# Altium schematic sheet MCU.SchDoc(MCU)
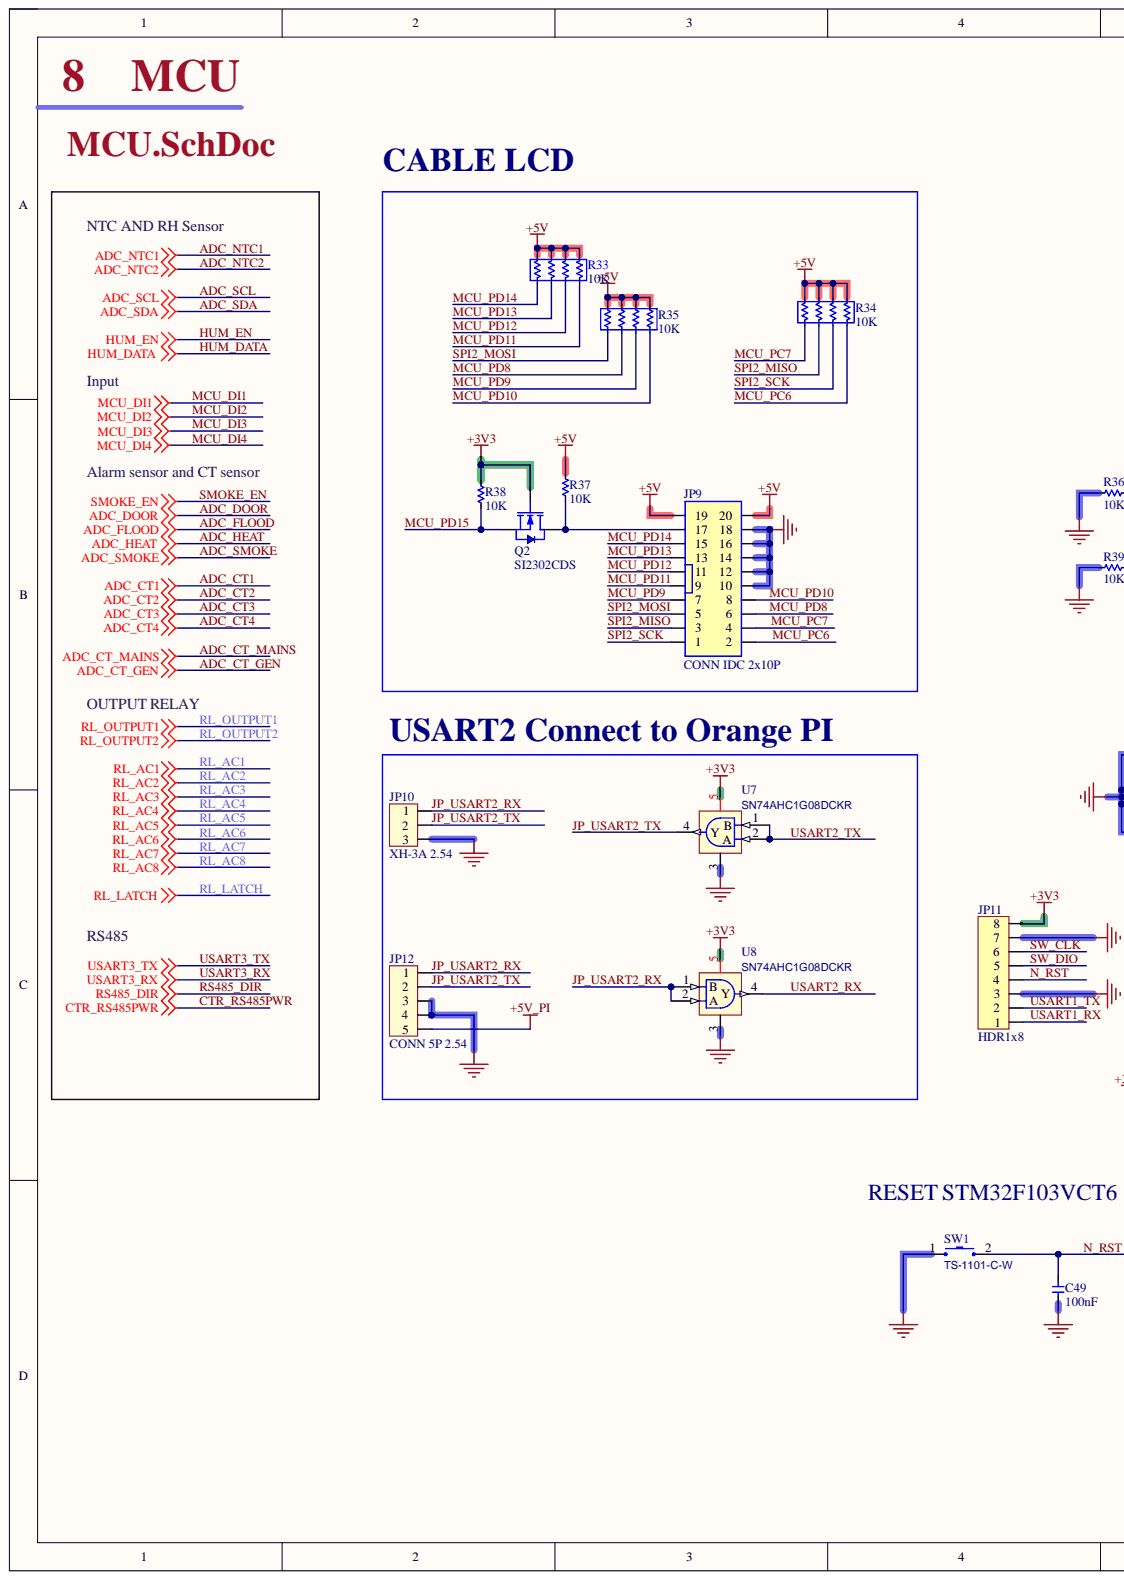
[diagram p0: MCU.SchDoc, left]
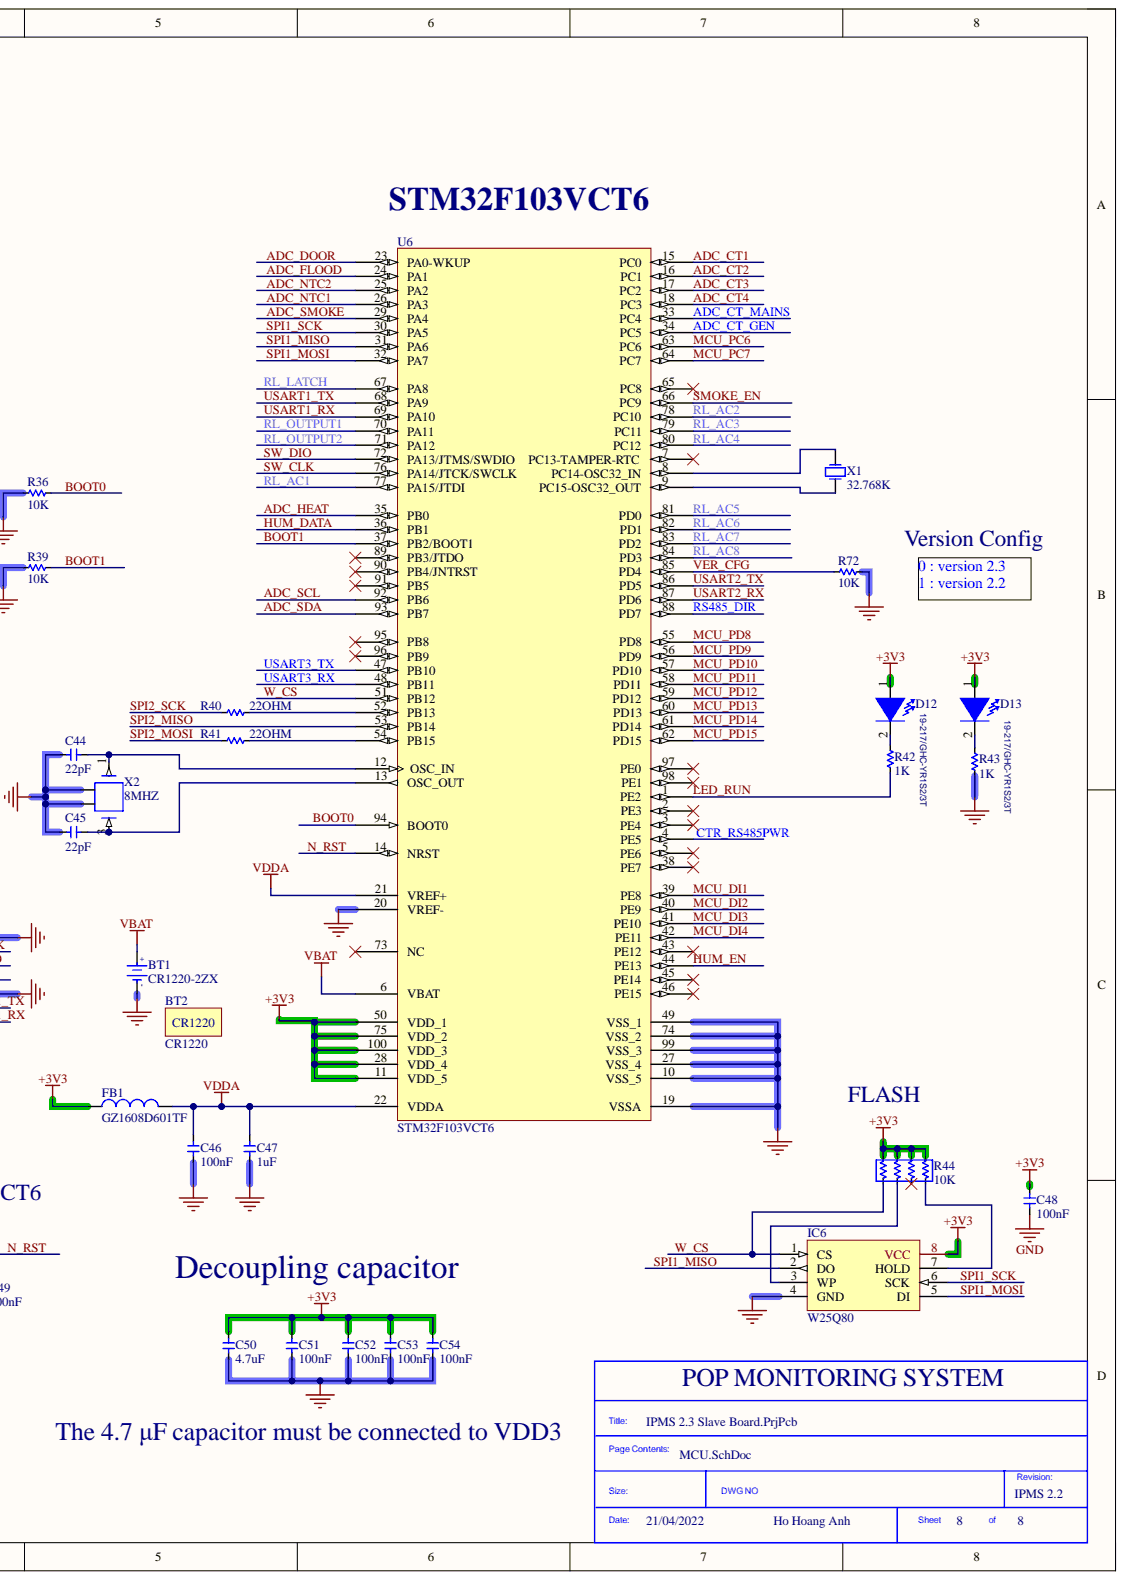
[diagram p1: MCU.SchDoc, right]

## Parts
BT1  CR1220-2ZX  [BAT-SMD_CR1220-2ZX]
BT2  CR1220  [CR1220]
C44  22pF  [C_0603]
C45  22pF  [C_0603]
C46  100nF  [C_0603]
C47  1uF  [C_0603]
C48  100nF  [C_0603]
C49  100nF  [C_0603]
C50  4.7uF  [C_0603]
C51  100nF  [C_0603]
C52  100nF  [C_0603]
C53  100nF  [C_0603]
C54  100nF  [C_0603]
D12  19-217/GHC-YR1S2/3T  [LED_0603]
D13  19-217/GHC-YR1S2/3T  [LED_0603]
FB1  GZ1608D601TF  [L_0603]
IC6  W25Q80  [SOIC-8_5.3x5.3x1.27P]
JP9  CONN IDC 2x10P  [IDC-TH_20P-P2.54-V-R2-C10-S2.54]
JP10  XH-3A 2.54  [XH-3A-2.54]
JP11  HDR1x8  [HDR1X8]
JP12  CONN 5P 2.54  [KF2510 2.54mm 5P]
Q2  SI2302CDS  [SOT-23-3]
R33  10K  [R_0603_x4]
R34  10K  [R_0603_x4]
R35  10K  [R_0603_x4]
R36  10K  [R_0603]
R37  10K  [R_0603]
R38  10K  [R_0603]
R39  10K  [R_0603]
R40  22OHM  [R_0603]
R41  22OHM  [R_0603]
R42  1K  [R_0603]
R43  1K  [R_0603]
R44  10K  [R_0603_x4]
R72  10K  [R_0603]
SW1  TS-1101-C-W  [SW-SMD_L6.0-W3.3-LS8.0]
U6  STM32F103VCT6  [LQFP-100_14.0x14.0x0.5P]
U7  SN74AHC1G08DCKR  [SOT-353_L2.2-W1.4-P0.65-LS2.4-BR]
U8  SN74AHC1G08DCKR  [SOT-353_L2.2-W1.4-P0.65-LS2.4-BR]
X1  32.768K  [SMD-3215_2P]
X2  8MHZ  [SMD-3225-4P]

## Nets
+3V3: C48.2 C50.2 C51.2 C52.2 C53.2 C54.2 D12.1 D13.1 FB1.1 IC6.8(VCC) JP11.8 Q2.1 R38.1 R44.1 R44.2 R44.3 R44.4 U6.11(VDD_5) U6.28(VDD_4) U6.50(VDD_1) U6.75(VDD_2) U6.100(VDD_3) U7.5 U8.5
+5V: JP9.19 JP9.20 R33.1 R33.2 R33.3 R33.4 R34.1 R34.2 R34.3 R34.4 R35.1 R35.2 R35.3 R35.4 R37.1
+5V_PI: JP12.5
GND: BT1.2 C44.2 C45.2 C46.1 C47.1 C48.1 C49.1 C50.1 C51.1 C52.1 C53.1 C54.1 IC6.4(GND) JP9.10 JP9.12 JP9.14 JP9.16 JP9.18 JP10.3 JP11.3 JP11.7 JP12.3 JP12.4 R36.2 R39.2 R43.2 R72.1 SW1.1 U6.10(VSS_5) U6.19(VSSA) U6.20(VREF-) U6.27(VSS_4) U6.49(VSS_1) U6.74(VSS_2) U6.99(VSS_3) U7.3 U8.3 X2.2 X2.4
VBAT: BT1.1 U6.6(VBAT)
VDDA: C46.2 C47.2 FB1.2 U6.21(VREF+) U6.22(VDDA)
ADC_CT1: U6.15(PC0)
ADC_CT2: U6.16(PC1)
ADC_CT3: U6.17(PC2)
ADC_CT4: U6.18(PC3)
ADC_CT_GEN: U6.34(PC5)
ADC_CT_MAINS: U6.33(PC4)
ADC_DOOR: U6.23(PA0-WKUP)
ADC_FLOOD: U6.24(PA1)
ADC_HEAT: U6.35(PB0)
ADC_NTC1: U6.26(PA3)
ADC_NTC2: U6.25(PA2)
ADC_SCL: U6.92(PB6)
ADC_SDA: U6.93(PB7)
ADC_SMOKE: U6.29(PA4)
BOOT0: R36.1 U6.94(BOOT0)
BOOT1: R39.1 U6.37(PB2/BOOT1)
CTR_RS485PWR: U6.4(PE5)
HUM_DATA: U6.36(PB1)
HUM_EN: U6.44(PE13)
JP_USART2_RX: JP10.1 JP12.1 U8.1(B) U8.2(A)
JP_USART2_TX: JP10.2 JP12.2 U7.4(Y)
LED_RUN: R42.2 U6.1(PE2)
MCU_DI1: U6.39(PE8)
MCU_DI2: U6.40(PE9)
MCU_DI3: U6.41(PE10)
MCU_DI4: U6.42(PE11)
MCU_PC6: JP9.2 R34.8 U6.63(PC6)
MCU_PC7: JP9.4 R34.5 U6.64(PC7)
MCU_PD8: JP9.6 R35.7 U6.55(PD8)
MCU_PD9: JP9.7 R35.6 U6.56(PD9)
MCU_PD10: JP9.8 R35.5 U6.57(PD10)
MCU_PD11: JP9.9 R33.8 U6.58(PD11)
MCU_PD12: JP9.11 R33.7 U6.59(PD12)
MCU_PD13: JP9.13 R33.6 U6.60(PD13)
MCU_PD14: JP9.15 R33.5 U6.61(PD14)
MCU_PD15: Q2.2 R38.2 U6.62(PD15)
N_RST: C49.2 JP11.4 SW1.2 U6.14(NRST)
RL_AC1: U6.77(PA15/JTDI)
RL_AC2: U6.78(PC10)
RL_AC3: U6.79(PC11)
RL_AC4: U6.80(PC12)
RL_AC5: U6.81(PD0)
RL_AC6: U6.82(PD1)
RL_AC7: U6.83(PD2)
RL_AC8: U6.84(PD3)
RL_LATCH: U6.67(PA8)
RL_OUTPUT1: U6.70(PA11)
RL_OUTPUT2: U6.71(PA12)
RS485_DIR: U6.88(PD7)
SMOKE_EN: U6.66(PC9)
SPI1_MISO: IC6.2(DO) U6.31(PA6)
SPI1_MOSI: IC6.5(DI) U6.32(PA7)
SPI1_SCK: IC6.6(SCK) U6.30(PA5)
SPI2_MISO: JP9.3 R34.6 U6.53(PB14)
SPI2_MOSI: JP9.5 R35.8 R41.2
SPI2_SCK: JP9.1 R34.7 R40.2
SW_CLK: JP11.6 U6.76(PA14/JTCK/SWCLK)
SW_DIO: JP11.5 U6.72(PA13/JTMS/SWDIO)
USART1_RX: JP11.1 U6.69(PA10)
USART1_TX: JP11.2 U6.68(PA9)
USART2_RX: U6.87(PD6) U8.4(Y)
USART2_TX: U6.86(PD5) U7.1(B) U7.2(A)
USART3_RX: U6.48(PB11)
USART3_TX: U6.47(PB10)
VER_CFG: R72.2 U6.85(PD4)
W_CS: IC6.1(CS) R44.5 U6.51(PB12)
NetC44_1: C44.1 U6.12(OSC_IN) X2.1
NetC45_1: C45.1 U6.13(OSC_OUT) X2.3
NetD12_2: D12.2 R42.1
NetD13_2: D13.2 R43.1
NetIC6_3: IC6.3(WP) R44.6
NetIC6_7: IC6.7(HOLD) R44.8
NetJP9_17: JP9.17 Q2.3 R37.2
NetR40_1: R40.1 U6.52(PB13)
NetR41_1: R41.1 U6.54(PB15)
NetU6_8: U6.8(PC14-OSC32_IN) X1.2
NetU6_9: U6.9(PC15-OSC32_OUT) X1.1

Not connected: R44 7; U6 2(PE3) 3(PE4) 5(PE6) 7(PC13-TAMPER-RTC) 38(PE7) 43(PE12) 45(PE14) 46(PE15) 65(PC8) 73(NC) 89(PB3/JTDO) 90(PB4/JNTRST) 91(PB5) 95(PB8) 96(PB9) 97(PE0) 98(PE1)

## Library data of the parts
BT1 = CR1220-2ZX: BATTERY HOLDER COIN 12.5MM SMD; Manufacturer: Q&J; Assembly Type: SMT Assembly; Component Kind: Standard; LCSC Part Number: C969906; Manufacturer Part Number: CR1220-2ZX; Package: BAT-SMD-1220; Library Name: IPMS 2.3 Slave Board.SCHLIB
BT2 = CR1220: Coin Cell Battery 3V 12 X 2.0 MM 35mA; Manufacturer: Panasonic Battery; Assembly Type: Hand Assembly; Component Kind: Standard; LCSC Part Number: N/A; Manufacturer Part Number: CR1220; Package: 12.5*2mm; Library Name: IPMS 2.3 Slave Board.SCHLIB
C44 C45 = CL10C220JB8NNNC: 22PF 50V 0603 ROHS; Manufacturer: Samsung Electro-Mechanics; Assembly Type: SMT Assembly; Component Kind: Standard; LCSC Part Number: C1653; Manufacturer Part Number: CL10C220JB8NNNC; Package: 0603; Library Name: IPMS 2.3 Slave Board.SCHLIB
C46 C48 C49 C51-C54 = CC0603KRX7R9BB104: 100nF 50V X7R ±10% 0603 Multilayer Ceramic Capacitors MLCC - SMD/SMT ROHS; Manufacturer: YAGEO; Assembly Type: SMT Assembly; Component Kind: Standard; LCSC Part Number: C14663; Manufacturer Part Number: CC0603KRX7R9BB104; Package: 0603; Library Name: IPMS 2.3 Slave Board.SCHLIB
C47 = CL10A105KB8NNNC: 1UF 50V 0603 ROHS; Manufacturer: Samsung Electro-Mechanics; Assembly Type: SMT Assembly; Component Kind: Standard; LCSC Part Number: C15849; Manufacturer Part Number: CL10A105KB8NNNC; Package: 0603; Library Name: IPMS 2.3 Slave Board.SCHLIB
C50 = CL10A475KO8NNNC: 4.7UF 16V 0603 ROHS; Manufacturer: Samsung Electro-Mechanics; Assembly Type: SMT Assembly; Component Kind: Standard; LCSC Part Number: C19666; Manufacturer Part Number: CL10A475KO8NNNC; Package: 0603; Library Name: IPMS 2.3 Slave Board.SCHLIB
D12 D13 = LED_GREEN_0603: LIGHT EMITTING DIODES (LED) GREEN 520~535NM 112~258MCD@20MA TOP VIEW 0603 ROHS; Manufacturer: Everlight Elec; Assembly Type: SMT Assembly; Component Kind: Standard; Digikey Part Number: 19-217/GHC-YR1S2/3T-ND; LCSC Part Number: C72043; Manufacturer Part Number: 19-217/GHC-YR1S2/3T; Package: LED_0603; Library Name: IPMS 2.3 Slave Board.SCHLIB
FB1 = FB_GZ1608D601TF: 600Ω @ 100MHz 1 200mA 450mΩ 0603 Ferrite Beads ROHS; Manufacturer: Sunlord; Assembly Type: SMT Assembly; Component Kind: Standard; LCSC Part Number: C1002; Manufacturer Part Number: GZ1608D601TF; Package: 0603; Library Name: IPMS 2.3 Slave Board.SCHLIB
IC6 = W25Q80DVSSIG: FLASH FLASH 8MB (1M X 8) SPI - DUAL/QUAD I/O SOIC-8_208MIL ROHS; Manufacturer: Winbond; Assembly Type: SMT Assembly; Component Kind: Standard; LCSC Part Number: C14086; Manufacturer Part Number: W25Q80DVSSIG; Package: SOIC-8_5.3x5.3x1.27P; Library Name: IPMS 2.3 Slave Board.SCHLIB
JP9 = IDC 2X10P Push-Pull: 2.54mm Straight Push - Pull 2.54mm 2 Plugin,P=2.54mm IDC Connectors ROHSM; Manufacturer: BOOMELE(Boom Precision Elec); Manufacturer Part Number: 302-S201; Assembly Type: Hand Soldering; Component Kind: Standard; LCSC Part Number: C3405; Package: 2.54 2X10P; Library Name: IPMS 2.3 Slave Board.SCHLIB
JP10 = XH-3A 2.54: 1x3P, Brass Plugin,P=2.54mm Wire To Board / Wire To Wire Connector ROHS; Manufacturer: China; Assembly Type: Hand Soldering; Component Kind: Standard; LCSC Part Number: N/A; Manufacturer Part Number: XH-3A 2.54; Package: CONN-TH_3P_XH Pitch=2.54; Library Name: IPMS 2.3 Slave Board.SCHLIB
JP11 = 61300811121: Connector Header Through Hole 8 position 0.100" (2.54mm); Manufacturer: Würth Elektronik; ComponentLink1 Description: Manufacturer; ComponentLink2 Description: Datasheet; Assembly Type: Hand Soldering; Component Kind: Standard; ComponentLink1URL: http://www.we-online.com; ComponentLink2URL: http://katalog.we-online.de/em/datasheet/6130xx143121.pdf; LCSC Part Number: N/A; Manufacturer Part Number: 61300811121; Package: Through, P=2.54; Library Name: IPMS 2.3 Slave Board.SCHLIB
JP12 = 61900511121: CONN HEADER VERT 5POS 2.54MM; Manufacturer: Wurth Electronics; Assembly Type: Hand Soldering; Component Kind: Standard; ComponentLink1Description: Link; ComponentLink1URL: https://www.thegioiic.com/products/dau-kf2510-2-54mm-5-chan-thang-xuyen-lo; LCSC Part Number: N/A; Manufacturer Part Number: 61900511121; Package: KF2510-5P 2.54mm; Library Name: IPMS 2.3 Slave Board.SCHLIB
Q2 = SI2302CDS-T1-GE3: MOSFET N-CH 20V 2.6A SOT-23; Manufacturer: Vishay Siliconix; Assembly Type: SMT Assembly; Component Kind: Standard; LCSC Part Number: C10488; Manufacturer Part Number: Si2302CDS-T1-GE3; Package: SOT-23-3; Library Name: IPMS 2.3 Slave Board.SCHLIB
R33-R35 R44 = 4D03WGJ0103T5E: 10KOHMS ±5% 1/16W 0603_X4 ROHS; Manufacturer: Uniroyal Elec; ComponentLink1 Description: Manufacturer; ComponentLink2 Description: Datasheet; Assembly Type: SMT Assembly; Component Kind: Standard; ComponentLink1URL: http://www.we-online.com; ComponentLink2URL: http://katalog.we-online.de/em/datasheet/6130xx21821.pdf; LCSC Part Number: C29718; Manufacturer Part Number: 4D03WGJ0103T5E; Package: 0603_x4; Library Name: IPMS 2.3 Slave Board.SCHLIB
R36-R39 R72 = 0603WAF1002T5E: 10KOHMS ±1% 1/10W 0603 ROHS; Manufacturer: Uniroyal Elec; Assembly Type: SMT Assembly; Component Kind: Standard; LCSC Part Number: C25804; Manufacturer Part Number: 0603WAF1002T5E; Package: 0603; Library Name: IPMS 2.3 Slave Board.SCHLIB
R40 R41 = 0603WAF220JT5E: 22OHMS ±1% 1/10W 0603 ROHS; Manufacturer: Uniroyal Elec; Assembly Type: SMT Assembly; Component Kind: Standard; LCSC Part Number: C23345; Manufacturer Part Number: 0603WAF220JT5E; Package: 0603; Library Name: IPMS 2.3 Slave Board.SCHLIB
R42 R43 = 0603WAF1001T5E: 1KOHMS ±1% 1/10W 0603 ROHS; Manufacturer: Uniroyal Elec; Assembly Type: SMT Assembly; Component Kind: Standard; LCSC Part Number: C21190; Manufacturer Part Number: 0603WAF1001T5E; Package: 0603; Library Name: IPMS 2.3 Slave Board.SCHLIB
SW1 = TS-1101-C-W: NO 50mA 6mm 100mΩ 50000 260gf 12V -30℃~+85℃ 3.6mm 2.5mm White Rectangle button Brick nogging SPST SMD Tactile Switches ROH; Manufacturer: XKB Connectivity; Assembly Type: SMT Assembly; Component Kind: Standard; LCSC Part Number: C318938; Manufacturer Part Number: TS-1101-C-W; Package: SW-SMD-2_3.6x6.0x8.0; Library Name: IPMS 2.3 Slave Board.SCHLIB
U6 = STM32F103VCT6: STM32 ARM-based 32-bit MCU with 64 Kbytes Flash, 100-pin LQFP, Industrial Temperature; Manufacturer: STMicroelectronics; Assembly Type: SMT Assembly; Component Kind: Standard; LCSC Part Number: C8313; Manufacturer Part Number: STM32F103VCT6; Package: LQFP-100_14x14x05P; Library Name: IPMS 2.3 Slave Board.SCHLIB
U7 U8 = SN74AHC1G08DCKR: 74 SERIES AND GATE 1 2V ~ 5.5V SOT-353 ROHS; Manufacturer: Texas Instruments; Assembly Type: SMT Assembly; Component Kind: Standard; LCSC Part Number: C122838; Manufacturer Part Number: SN74AHC1G08DCKR; Package: SOT-353; Library Name: IPMS 2.3 Slave Board.SCHLIB
X1 = XTAL_32KHZ_3215: 32.768K SMD CRYSTAL RESONATORS 32.768KHZ ±20PPM SMD-3215_2P ROHS; Manufacturer: Seiko Epson; Manufacturer Part Number: Q13FC1350000400; Assembly Type: SMT Assembly; Component Kind: Standard; LCSC Part Number: C32346; Manufacturer 2: QST; Manufacturer Part Number 2: QT3C-32.768KDZB-T; Package: SMD-3215_2P; Library Name: IPMS 2.3 Slave Board.SCHLIB
X2 = XTAL_8MHZ_3225: SMD CRYSTAL RESONATORS 8MHZ SMD-3225-4P ROHS; Manufacturer: KDS Daishinku; Manufacturer Part Number: 1C208000CE0Q; Assembly Type: SMT Assembly; Component Kind: Standard; Digikey Part Number: 2151-RH100-8.000-10-1010-EXT-TR-ND; LCSC Part Number: C133366; Manufacturer Part Number 2: RH100-8.000-10-1010-EXT-TR; Package: SMD-3225_4P; Library Name: IPMS 2.3 Slave Board.SCHLIB
